# Altium schematic sheet alarm_timing.SchDoc
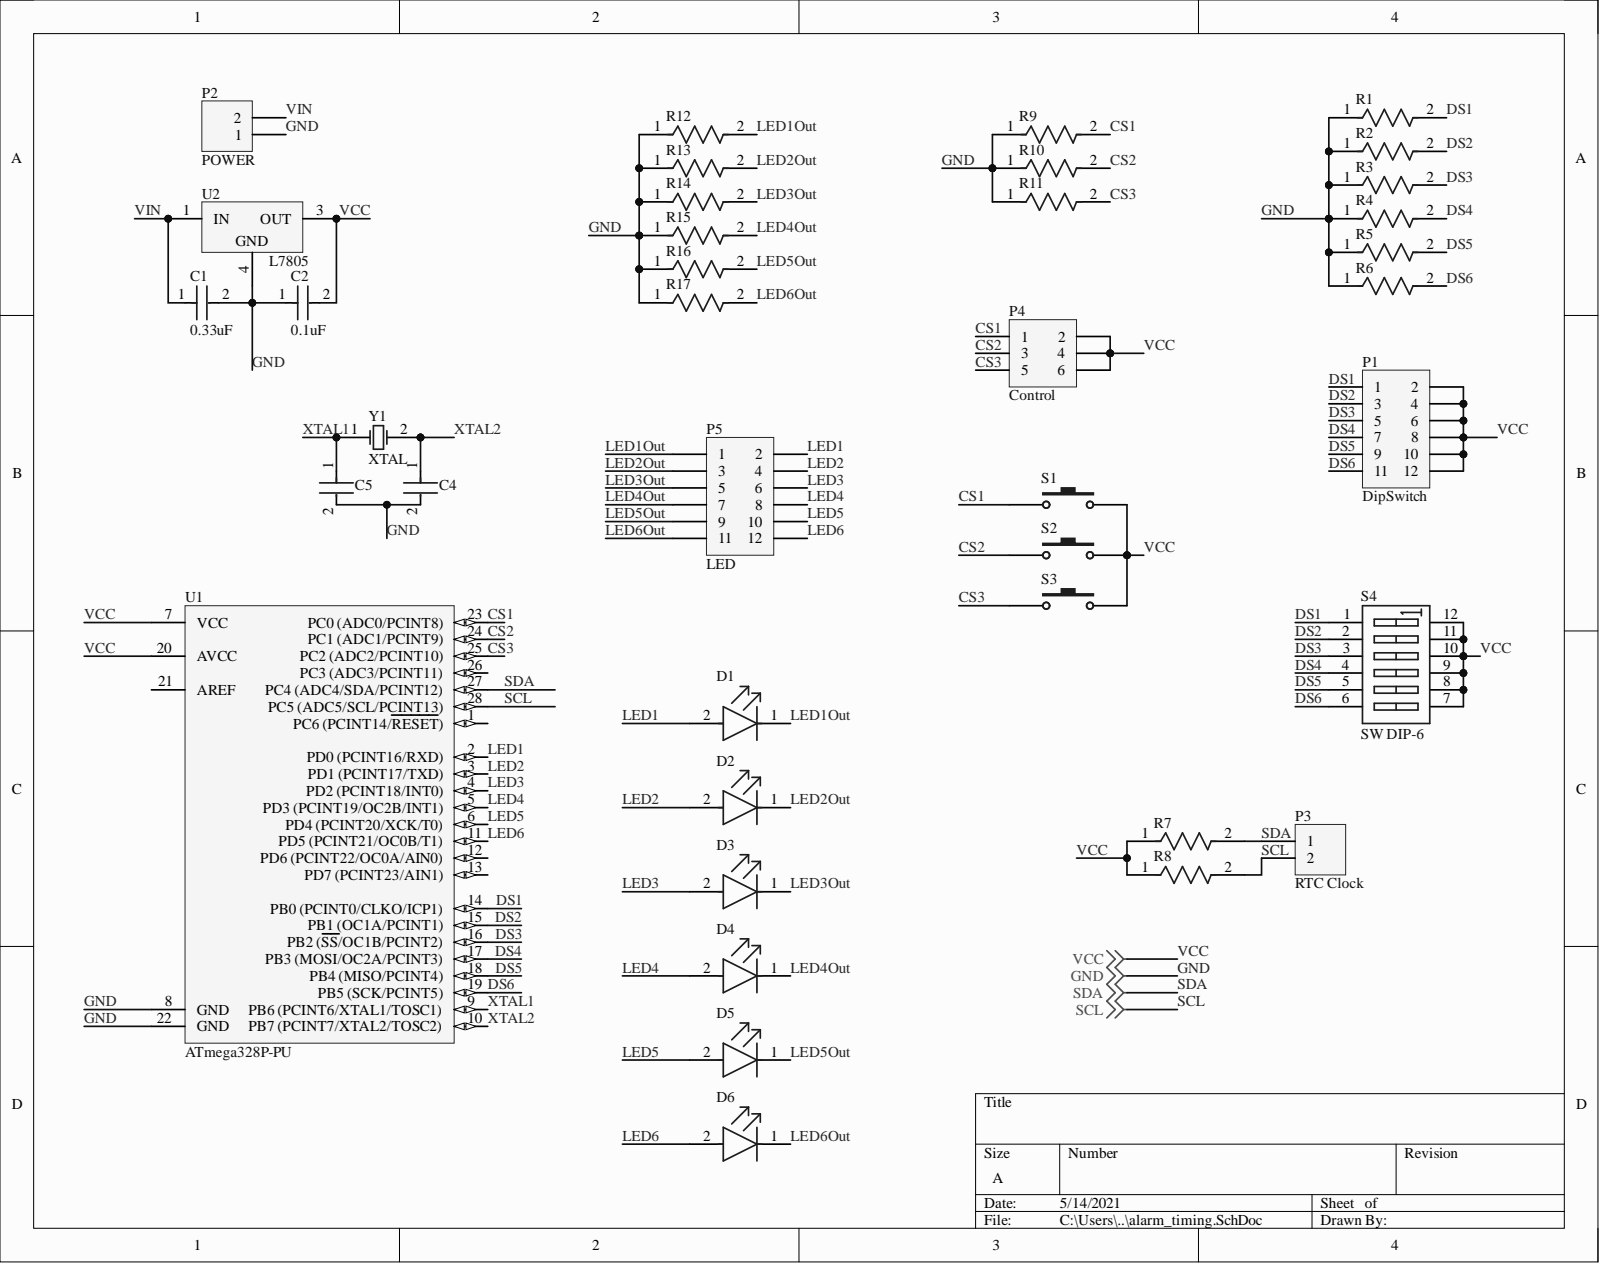
[diagram p0: alarm_timing.SchDoc]

## Parts
C1  0.33uF  [FP-C1206C-EB-MFG]
C2  0.1uF  [FP-C1206C-EB-MFG]
C4  (no value)  [FP-C1206C-EB-MFG]
C5  (no value)  [FP-C1206C-EB-MFG]
D1  (no value)  [FP-598-82-MFG]
D2  (no value)  [FP-598-82-MFG]
D3  (no value)  [FP-598-82-MFG]
D4  (no value)  [FP-598-82-MFG]
D5  (no value)  [FP-598-82-MFG]
D6  (no value)  [FP-598-82-MFG]
P1  DipSwitch  [HDR2X6]
P2  POWER  [HDR1X2]
P3  RTC Clock  [HDR1X2]
P4  Control  [HDR2X3]
P5  LED  [HDR2X6]
R1  (no value)  [FP-CR1206-IPC_B]
R2  (no value)  [FP-CR1206-IPC_B]
R3  (no value)  [FP-CR1206-IPC_B]
R4  (no value)  [FP-CR1206-IPC_B]
R5  (no value)  [FP-CR1206-IPC_B]
R6  (no value)  [FP-CR1206-IPC_B]
R7  (no value)  [FP-CR1206-IPC_B]
R8  (no value)  [FP-CR1206-IPC_B]
R9  (no value)  [FP-CR1206-IPC_B]
R10  (no value)  [FP-CR1206-IPC_B]
R11  (no value)  [FP-CR1206-IPC_B]
R12  (no value)  [FP-CR1206-IPC_B]
R13  (no value)  [FP-CR1206-IPC_B]
R14  (no value)  [FP-CR1206-IPC_B]
R15  (no value)  [FP-CR1206-IPC_B]
R16  (no value)  [FP-CR1206-IPC_B]
R17  (no value)  [FP-CR1206-IPC_B]
S1  (no value)  [SPST-2]
S2  (no value)  [SPST-2]
S3  (no value)  [SPST-2]
S4  SW DIP-6  [DIP-12/SW]
U1  ATmega328P-PU  [28P3]
U2  L7805  [TO-263_N]
Y1  XTAL  [R38]

## Nets
CS1: P4.1 R9.2 S1.1 U1.23(PC0_(ADC0/PCINT8))
CS2: P4.3 R10.2 S2.1 U1.24(PC1_(ADC1/PCINT9))
CS3: P4.5 R11.2 S3.1 U1.25
DS1: P1.1 R1.2 S4.1 U1.14(PB0_(PCINT0/CLKO/ICP1))
DS2: P1.3 R2.2 S4.2 U1.15(PB1_(OC1A/PCINT1))
DS3: P1.5 R3.2 S4.3 U1.16(PB2_(SS/OC1B/PCINT2))
DS4: P1.7 R4.2 S4.4 U1.17(PB3_(MOSI/OC2A/PCINT3))
DS5: P1.9 R5.2 S4.5 U1.18(PB4_(MISO/PCINT4))
DS6: P1.11 R6.2 S4.6 U1.19(PB5_(SCK/PCINT5))
GND: C1.2 C2.1 C4.2 C5.2 P2.1 R1.1 R2.1 R3.1 R4.1 R5.1 R6.1 R9.1 R10.1 R11.1 R12.1 R13.1 R14.1 R15.1 R16.1 R17.1 U1.8(GND) U1.22(GND) U2.4
LED1: D1.2 P5.2 U1.2(PD0_(PCINT16/RXD))
LED1Out: D1.1 P5.1 R12.2
LED2: D2.2 P5.4 U1.3(PD1_(PCINT17/TXD))
LED2Out: D2.1 P5.3 R13.2
LED3: D3.2 P5.6 U1.4(PD2_(PCINT18/INT0))
LED3Out: D3.1 P5.5 R14.2
LED4: D4.2 P5.8 U1.5(PD3_(PCINT19/OC2B/INT1))
LED4Out: D4.1 P5.7 R15.2
LED5: D5.2 P5.10 U1.6(PD4_(PCINT20/XCK/T0))
LED5Out: D5.1 P5.9 R16.2
LED6: D6.2 P5.12 U1.11(PD5_(PCINT21/OC0B/T1))
LED6Out: D6.1 P5.11 R17.2
SCL: P3.2 R8.2 U1.28(PC5_(ADC5/SCL/PCINT13))
SDA: P3.1 R7.2 U1.27
VCC: C2.2 P1.2 P1.4 P1.6 P1.8 P1.10 P1.12 P4.2 P4.4 P4.6 R7.1 R8.1 S1.2 S2.2 S3.2 S4.7 S4.8 S4.9 S4.10 S4.11 S4.12 U1.7(VCC) U1.20(AVCC) U2.3
VIN: C1.1 P2.2 U2.1
XTAL1: C5.1 U1.9 Y1.1
XTAL2: C4.1 U1.10 Y1.2

Not connected: U1 1(PC6_(PCINT14/RESET)) 12(PD6_(PCINT22/OC0A/AIN0)) 13(PD7_(PCINT23/AIN1)) 21(AREF) 26(PC3_(ADC3/PCINT11))

## Library data of the parts
C1 C2 = CMP-03020-001426-1: 1206 C 330nF Ceramic Multilayer Capacitor; 25 VDC; +125degC; X7R Dielec; +/-10%; Manufacturer: KEMET; Manufacturer Part Number: C1206C334K3RACTU; Automotive Grade: Grade 0; Capacitance: 0.33 μF; Temperature Coefficient: 15%; Tolerance: 0.1; Value: 0.33 μF; Voltage Rating: 25 V; Automotive: Yes; Category: Cap; Component Kind: Standard; ComponentLink2Description: Datasheet; ComponentLink2URL: https://content.kemet.com/datasheets/KEM_C1007_X8R_ULTRA_150C_SMD.pdf; Device Class L1: Passive Components; Device Class L2: Capacitors; Device Class L3: Ceramic Capacitors; Digikey Description: 1206 C 330nF Ceramic Multilayer Capacitor; 25 VDC; +125degC; X7R Dielec; +/-10%; Height: 0.88mm; Ipc Land Pattern Name: CAPC320160X78; Lead Free: Yes; Library Name: Altium Content Vault; Material: Ceramic; Mouser Description: Multilayer Ceramic Capacitors MLCC - SMD/SMT 25volts 0.33uF X7R 10%; Octopart: https://octopart.com/c1206c334k3ractu-kemet-133095; Package: C1206C-EB; RoHS: TRUE; Temperature: -55°C to +150°C; Temperature Characteristic: X7R; Temperature Range High: +150°C; Temperature Range Low: -55°C
C4 C5 = CMP-03020-000153-1: CAP CER 0.1UF 100V X7R 1206; Manufacturer: KEMET; Manufacturer Part Number: C1206C104K1RACTU; Automotive Grade: Grade 0; Capacitance: 0.10 μF; Material: Ceramic; Temperature Coefficient: 15%; Tolerance: 0.1; Value: 0.10 μF; Automotive: Yes; Category: Cap; Component Kind: Standard; ComponentLink2Description: Datasheet; ComponentLink2URL: https://content.kemet.com/datasheets/KEM_C1007_X8R_ULTRA_150C_SMD.pdf; Device Class L1: Passive Components; Device Class L2: Capacitors; Device Class L3: Ceramic Capacitors; Digikey Description: CAP CER 0.1UF 100V X7R 1206; Height: 0.88mm; Ipc Land Pattern Name: CAPC320160X78; Lead Free: Yes; Library Name: Altium Content Vault; Mouser Description: CAP CER 0.1UF 100V X7R 1206; Octopart: https://octopart.com/c1206c104k1ractu-kemet-89521; Package: C1206C-EB; RoHS: TRUE; Temperature: -55°C to +150°C; Temperature Characteristic: X7R; Temperature Range High: +150°C; Temperature Range Low: -55°C; Voltage Rating: 100 V
D1-D6 = CMP-15384-000005-1: LED RED CLEAR 1206 SMD; Manufacturer: Dialight; Manufacturer Part Number: 598-8210-107F; Forward Current: 20mA; Forward Voltage: 2.1V; Led Orientation: Top View; Luminous Intensity: 55mcd; Max Junction Temp: +105°C; Power Dissipation: 72mW; Reverse Voltage: 5V; Viewing Angle: 140°; Wavelength: 633nm; Automotive: No; Category: Diode; Colour: Red; Component Kind: Standard; ComponentLink2Description: Datasheet; ComponentLink2URL: http://www.dialightsignalsandcomponents.com/Assets/Brochures_And_Catalogs/Indication/CBI_SMD_new/Dialight_CBI_data_598-1206_Apr2018.pdf; Device Class L1: Optoelectronics; Device Class L2: LEDs; Device Class L3: unset; Digikey Description: LED RED CLEAR 1206 SMD; Height: 0.9mm; Lead Free: Yes; Library Name: Altium Content Vault; Mouser Description: 598 Series Red 1206 3.2 x 1.6 x 0.7 mm 140° Water Clear 55 mcd 2 V LED SMT; Octopart: https://octopart.com/598-8210-107f-dialight-868600; Package: 598-82; RoHS: TRUE; Temperature: -40°C to +100°C; Temperature Range High: +100°C; Temperature Range Low: -40°C
P1 P5 = Header 6X2: Header, 6-Pin, Dual row; Component Kind: Standard; LatestRevisionDate: 17-Jul-2002; LatestRevisionNote: Re-released for DXP Platform.; Library Name: Miscellaneous Connectors.IntLib; Signal Integrity: Connector; Publisher: Altium Limited
P2 P3 = Header 2: Header, 2-Pin; Component Kind: Standard; LatestRevisionDate: 17-Jul-2002; LatestRevisionNote: Re-released for DXP Platform.; Library Name: Miscellaneous Connectors.IntLib; Signal Integrity: Connector; Publisher: Altium Limited
P4 = Header 3X2: Header, 3-Pin, Dual row; Component Kind: Standard; LatestRevisionDate: 17-Jul-2002; LatestRevisionNote: Re-released for DXP Platform.; Library Name: Miscellaneous Connectors.IntLib; Signal Integrity: Connector; Publisher: Altium Limited
R1-R17 = CMP-07231-005593-1: Thick Film Chip Resistors 1206 10kΩ 0.25W 5% 200ppm/°C; Max Power Dissipation: 250mW; Resistance: 10kR; Voltage Rating: 200V; Case Code (Imperial): 1206; Case Code (Metric): 3216; Case/Package: 1206; Component Kind: Standard; Composition: Thick Film; Contact Plating: Tin; Depth: 1.6mm; Features: Moisture Resistant; Height: 0.6mm; Length: 3.2mm; Library Name: Altium Content Vault; Max Operating Temperature: 155°C; Military Standard: Not; Min Operating Temperature: -55°C; Mount: Surface Mount; Number of Pins: 2; Number of Terminations: 2; Package Quantity: 1; Packaging: Tape and Reel; Power Rating: 250mW; Radiation Hardening: No; REACH SVHC: No SVHC; Temperature Coefficient: 200ppm/°C; Termination: SMD/SMT; Thickness: 610um; Tolerance: 5%; Voltage Rating (DC): 200V; Width: 1.6mm
S1-S3 = SW-PB: Switch; Component Kind: Standard; LatestRevisionDate: 17-Jul-2002; LatestRevisionNote: Re-released for DXP Platform.; Library Name: Miscellaneous Devices.IntLib; PackageDescription: Switch; 2 Leads; PackageReference: SPST-2; Published: 24-Mar-1999; Publisher: Altium Limited
S4 = SW DIP-6: DIP Switch, 6 Position, SPST; Component Kind: Standard; LatestRevisionDate: 17-Jul-2002; LatestRevisionNote: Re-released for DXP Platform.; Library Name: Miscellaneous Devices.IntLib; PackageDescription: DIP Switch; 12 Leads; PackageReference: DIP-12/SW; Published: 24-Mar-1999; Simulation: dpsw6; Publisher: Altium Limited
U1 = CMP-0095-00013-2: 8-bit AVR Microcontroller, 32KB Flash, 1KB EEPROM, 2KB SRAM, 28-pin PDIP, Industrial Grade (-40°C to 85°C); Component Kind: Standard; ComponentLink1Description: Manufacturer URL; ComponentLink1URL: http://www.atmel.com/; ComponentLink2Description: ATmega48A/48PA/88A/88PA/168A/168PA/328/328P Datasheet; ComponentLink2URL: http://www.atmel.com/dyn/resources/prod_documents/8271.pdf; ComponentLink3Description: ATmega48A/48PA/88A/88PA/168A/168PA/328/328P Summary; ComponentLink3URL: http://www.atmel.com/dyn/resources/prod_documents/8271S.pdf; DatasheetVersion: revD, May-2011; Library Name: Altium Content Vault; PackageDescription: 28-lead (0.300"/7.62mm Wide) Plastic Dual Inline Package (PDIP); PackageReference: 28P3; PackageVersion: revB, Sep-2001; RevisionNote: DatasheetVersion updated. URLs added.
U2 = CMP-0244-00214-1: Positive Voltage Regulator, 3-Pin D2PAK, Tape and Reel; Component Kind: Standard; ComponentLink1Description: Manufacturer URL; ComponentLink1URL: http://www.st.com; ComponentLink2Description: Datasheet; ComponentLink2URL: http://www.st.com/internet/com/TECHNICAL_RESOURCES/TECHNICAL_LITERATURE/DATASHEET/CD00000444.pdf; DatasheetVersion: 16/11/2011; Library Name: Altium Content Vault; PackageDescription: 3-Pin Transistor Outline Package 2.54 mm Pitch, 15.4 mm Lead Span (D2PAK); PackageReference: TO-263; PackageVersion: 2011
Y1 = XTAL: Crystal Oscillator; Component Kind: Standard; LatestRevisionDate: 17-Jul-2002; LatestRevisionNote: Re-released for DXP Platform.; Library Name: Miscellaneous Devices.IntLib; PackageDescription: Crystal, Thru-Hole; 2 Leads; Body 3.1 x 8.2 mm (Dia.xH); PackageReference: R38; Published: 8-Jun-2000; Simulation: XTAL; Publisher: Altium Limited
